# Altium schematic sheet Relay-1.SchDoc(Relay-1)
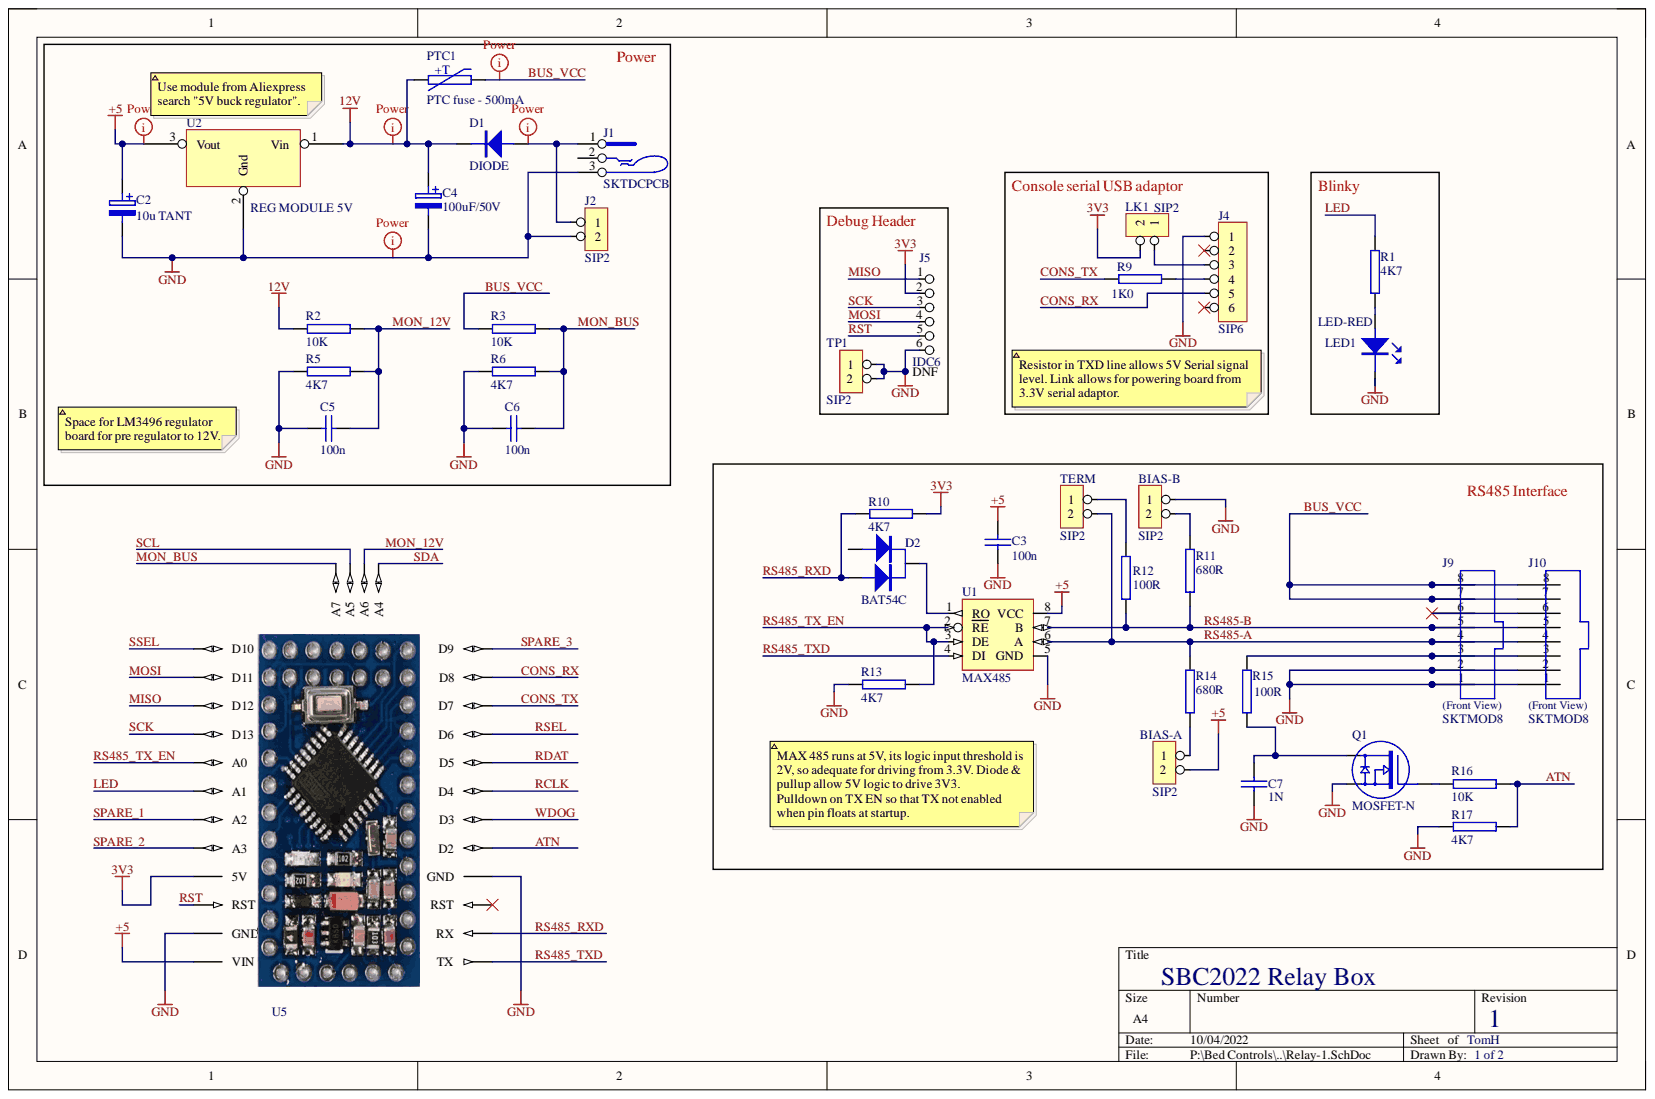
[diagram p0: Relay-1.SchDoc]

## Parts
BIAS-A  SIP2  [SIP2]
BIAS-B  SIP2  [SIP2]
C2  10u TANT  [TC6032-2412]
C3  100n  [SMD1206]
C4  100uF/50V  [RB.2/.4]
C5  100n  [SMD1206]
C6  100n  [SMD1206]
C7  1N  [SMD1206]
D1  DIODE  [DO-214]
D2  BAT54C  [SOT-23]
J1  SKTDCPCB  [SKTDCPCB]
J2  SIP2  [2PAD.1.2 TerminalBlock]
J4  SIP6  [SIP6]
J5  IDC6  [IDC6]
J9  SKTMOD8  [SKTMOD8PCB]
J10  SKTMOD8  [SKTMOD8PCB]
LED1  LED-RED  [LED]
LK1  SIP2  [SIP2]
PTC1  PTC fuse - 500mA  [SMD1206_0805]
Q1  MOSFET-N  [SOT-23]
R1  4K7  [SMD1206]
R2  10K  [SMD1206]
R3  10K  [SMD1206]
R5  4K7  [SMD1206]
R6  4K7  [SMD1206]
R9  1K0  [SMD1206]
R10  4K7  [SMD1206]
R11  680R  [SMD1206]
R12  100R  [SMD1206]
R13  4K7  [SMD1206]
R14  680R  [SMD1206]
R15  100R  [SMD1206]
R16  10K  [SMD1206]
R17  4K7  [SMD1206]
TERM  SIP2  [SIP2]
TP1  SIP2  [SIP2]
U1  MAX485  [SMD8A]
U2  REG MODULE 5V  [REG-MOD-V]
U5  ArduinoMiniPro2  [ArduinoMiniPro4]  (value not drawn)

## Nets
3V3: J5.2 LK1.2 R10.2 U5.JP6-4(5V)
12V: C4.1 D1.K PTC1.2 R2.1 U2.1
+5: BIAS-A.2 C2.1 C3.2 U1.8(VCC) U2.3 U5.JP6-1(VIN)
GND: BIAS-B.1 C2.2 C3.1 C4.2 C5.1 C6.1 C7.1 J1.3 J2.2 J4.1 J5.6 J9.1 J9.2 J10.1 J10.2 LED1.K Q1.2 R5.1 R6.1 R13.1 R17.1 TP1.1 TP1.2 U1.5(GND) U2.2 U5.JP6-2(GND) U5.JP7-9(GND)
ATN: R16.2 R17.2 U5.JP7-8(D2)
BUS_VCC: J9.7 J9.8 J10.7 J10.8 PTC1.1 R3.1
CONS_RX: J4.5 U5.JP7-2(D8)
CONS_TX: R9.1 U5.JP7-3(D7)
LED: R1.2 U5.JP6-7(A1)
MISO: J5.1 U5.JP6-10(D12)
MON_12V: C5.2 R2.2 R5.2 U5.JP3-2(A6)
MON_BUS: C6.2 R3.2 R6.2 U5.JP3-1(A7)
MOSI: J5.4 U5.JP6-11(D11)
RCLK: U5.JP7-6(D4)
RDAT: U5.JP7-5(D5)
RS485-A: J9.4 J10.4 R14.2 TERM.2 U1.6(A)
RS485-B: J9.5 J10.5 R11.1 R12.1 U1.7(B)
RS485_RXD: D2.1 R10.1 U5.JP7-11(RX)
RS485_TX_EN: R13.2 U1.2(RE) U1.3(DE) U5.JP6-8(A0)
RS485_TXD: U1.4(DI) U5.JP7-12(TX)
RSEL: U5.JP7-4(D6)
RST: J5.5 U5.JP6-3(RST)
SCK: J5.3 U5.JP6-9(D13)
SCL: U5.JP2-2(A5)
SDA: U5.JP2-1(A4)
SPARE_1: U5.JP6-6(A2)
SPARE_2: U5.JP6-5(A3)
SPARE_3: U5.JP7-1(D9)
SSEL: U5.JP6-12(D10)
WDOG: U5.JP7-7(D3)
NetBIAS-A_1: BIAS-A.1 R14.1
NetBIAS-B_2: BIAS-B.2 R11.2
NetC7_2: C7.2 Q1.3 R15.1
NetD1_A: D1.A J1.1 J2.1
NetD2_3: D2.3 U1.1(RO)
NetJ4_3: J4.3 LK1.1
NetJ4_4: J4.4 R9.2
NetJ9_3: J9.3 J10.3 R15.2
NetJ9_6: J9.6 J10.6
NetLED1_A: LED1.A R1.1
NetQ1_1: Q1.1 R16.1
NetR12_2: R12.2 TERM.1

Not connected: D2 2; J1 2; J4 2 6; U5 JP7-10(RST)

## Library data of the parts
C2 C4 = ELECTRO: Electrolytic Cap; Component Kind: Standard; Library Name: 2015TslibSch.LIB
C3 C5-C7 = CAP: Non-Polarised Cap; Component Kind: Standard; Library Name: v4_TslibSch.LIB
D2 = DIODE2CC: Dual Diode Common Cathode; Component Kind: Standard; Library Name: v4_Tslib.SCHLIB
LED1 = LED-3MM-RD: Component Kind: Standard; Library Name: 2015TslibSch.LIB
PTC1 = RES_PTC: PTC thermistor for current limit; Component Kind: Standard; Library Name: v4_Tslib.SCHLIB
R1-R3 R5 R6 R9-R17 = RES2: Resistor; Component Kind: Standard; Library Name: v4_TslibSch.LIB
TP1 = SIP2: GND; Component Kind: Standard; Library Name: v4_Tslib.SCHLIB
U1 = MAX485: RS485 Tranceiver; Component Kind: Standard; Library Name: dxp_DMISC.SchLib
U2 = VOLTREG-78xx: TO220 mounting Volt Regulators; Component Kind: Standard; Library Name: v4_TslibSch.LIB
BIAS-A BIAS-B J2 LK1 TERM = SIP2: Component Kind: Standard; Library Name: v4_Tslib.SCHLIB
D1 = DIODE: Component Kind: Standard; Library Name: v4_Tslib.SCHLIB
J1 = SKTDCPCB: Component Kind: Standard; Library Name: v4_Tslib.SCHLIB
J4 = SIP6: Component Kind: Standard; Library Name: v4_Tslib.SCHLIB
J5 = IDC6: Component Kind: Standard; Library Name: v4_Tslib.SCHLIB
J9 J10 = SKTMOD8: Component Kind: Standard; Library Name: v4_Tslib.SCHLIB
Q1 = MOSFET-N: Component Kind: Standard; Library Name: v4_Tslib.SCHLIB
U5 = ArduinoMiniPro2: Component Kind: Standard; Library Name: v4_MiscSch.LIB
